# Altium schematic sheet Motor_Driver.SchDoc
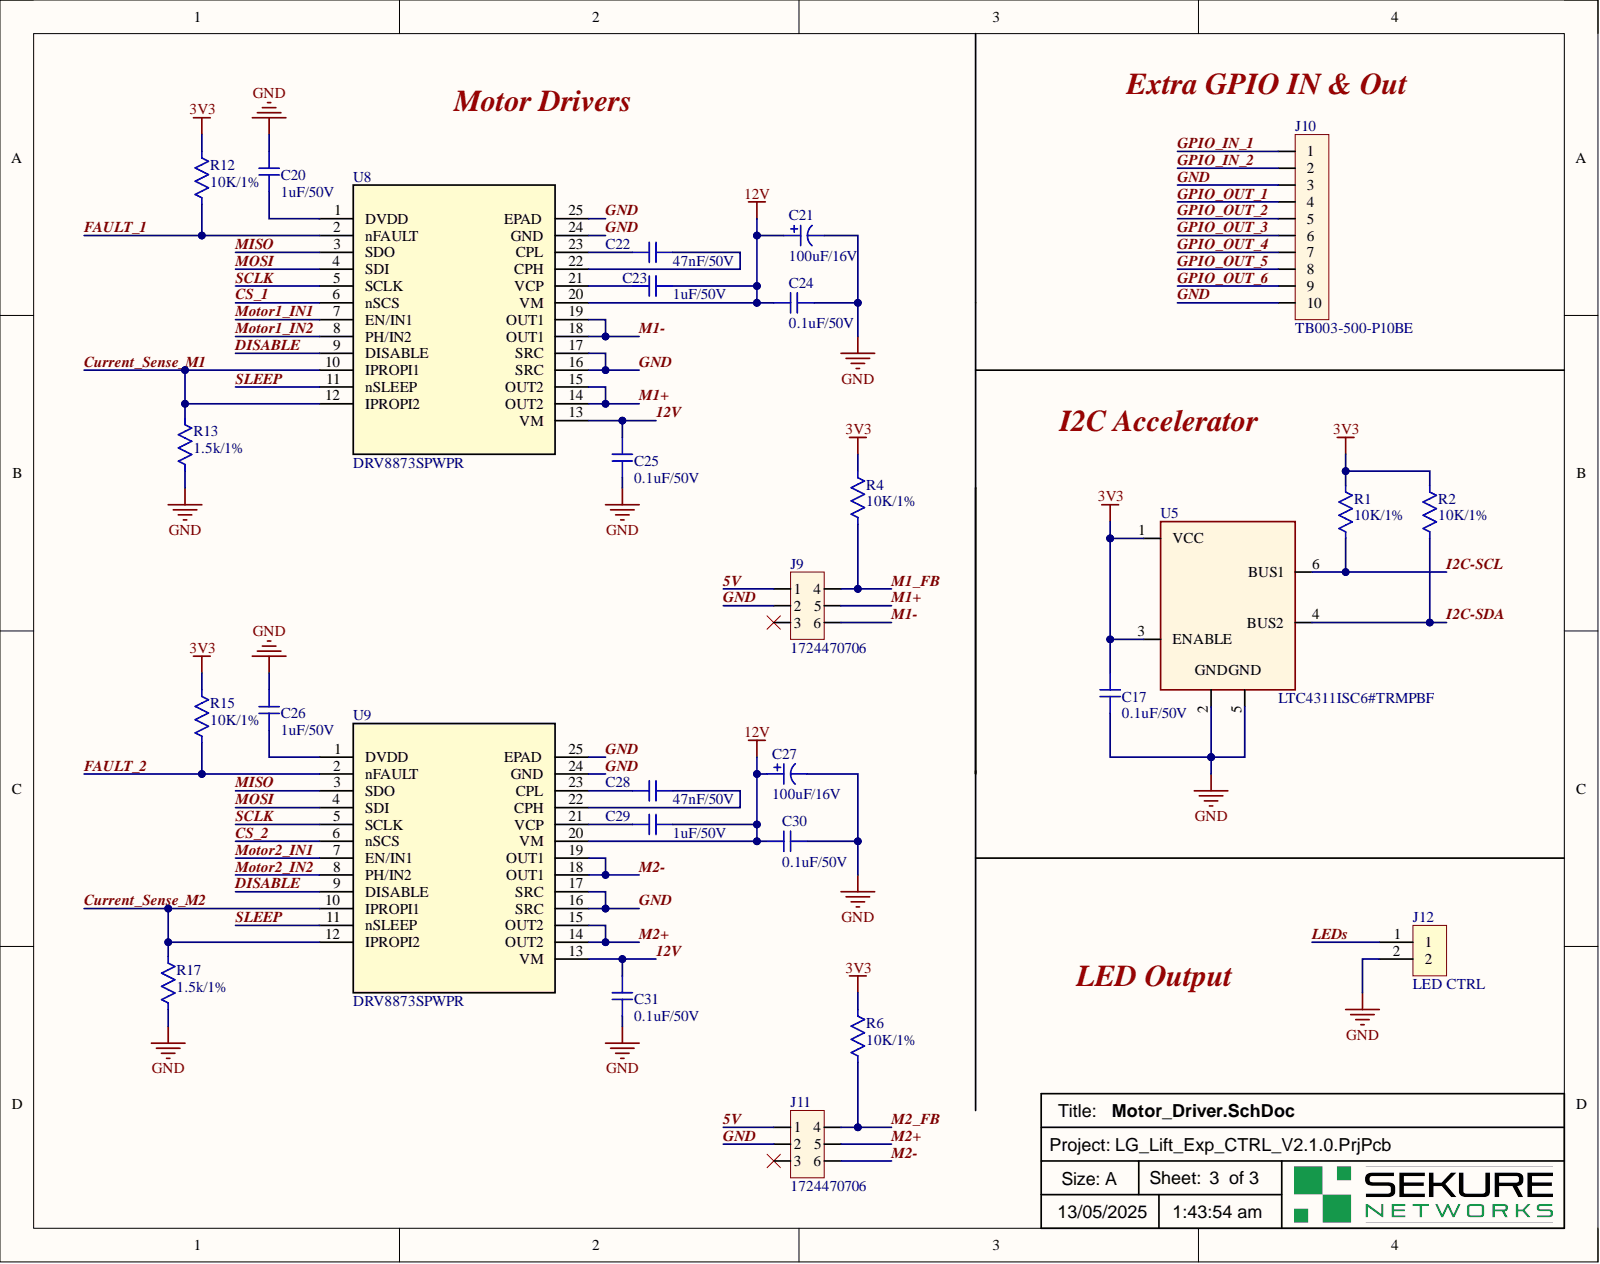
[diagram p0: Motor_Driver.SchDoc]

## Parts
C17  value 0.1uF/50V  [CAP 0603]  (hidden comment: Ceramic Capacitor)
C20  value 1uF/50V  [CAP 0603]  (hidden comment: Ceramic Capacitor)
C21  value 100uF/16V  [CAP SMD 6.6 x 6.6]  (hidden comment: Capacitor)
C22  value 47nF/50V  [CAP 0603]  (hidden comment: Ceramic Capacitor)
C23  value 1uF/50V  [CAP 0603]  (hidden comment: Ceramic Capacitor)
C24  value 0.1uF/50V  [CAP 0603]  (hidden comment: Ceramic Capacitor)
C25  value 0.1uF/50V  [CAP 0603]  (hidden comment: Ceramic Capacitor)
C26  value 1uF/50V  [CAP 0603]  (hidden comment: Ceramic Capacitor)
C27  value 100uF/16V  [CAP SMD 6.6 x 6.6]  (hidden comment: Capacitor)
C28  value 47nF/50V  [CAP 0603]  (hidden comment: Ceramic Capacitor)
C29  value 1uF/50V  [CAP 0603]  (hidden comment: Ceramic Capacitor)
C30  value 0.1uF/50V  [CAP 0603]  (hidden comment: Ceramic Capacitor)
C31  value 0.1uF/50V  [CAP 0603]  (hidden comment: Ceramic Capacitor)
J9  value 1724470706  [1724470706]  (hidden comment: Connector)
J10  value TB003-500-P10BE  [TB003-500-P10BE]  (hidden comment: Connector)
J11  value 1724470706  [1724470706]  (hidden comment: Connector)
J12  value LED CTRL  [TB003-500-P02BE]  (hidden comment: Connector)
R1  value 10K/1%  [RES 0603]  (hidden comment: Resistor)
R2  value 10K/1%  [RES 0603]  (hidden comment: Resistor)
R4  value 10K/1%  [RES 0603]  (hidden comment: Resistor)
R6  value 10K/1%  [RES 0603]  (hidden comment: Resistor)
R12  value 10K/1%  [RES 0603]  (hidden comment: Resistor)
R13  value 1.5k/1%  [RES 0603]  (hidden comment: Resistor)
R15  value 10K/1%  [RES 0603]  (hidden comment: Resistor)
R17  value 1.5k/1%  [RES 0603]  (hidden comment: Resistor)
U5  value LTC4311ISC6#TRMPBF  [SC-70-6, 6-TSSOP, SC-88, SOT-363]  (hidden comment: IC)
U8  value DRV8873SPWPR  [24-HTSSOP]  (hidden comment: IC)
U9  value DRV8873SPWPR  [24-HTSSOP]  (hidden comment: IC)

## Nets
3V3: C17.2 R1.1 R2.1 R4.2 R6.2 R12.2 R15.2 U5.1(VCC) U5.3(ENABLE)
5V: J9.1 J11.1
12V: C21.1 C23.1 C24.1 C25.2 C27.1 C29.1 C30.1 C31.1 U8.13(VM) U8.20(VM) U9.13(VM) U9.20(VM)
CS_1: U8.6(nSCS)
CS_2: U9.6(nSCS)
Current_Sense_M1: R13.2 U8.10(IPROPI1) U8.12(IPROPI2)
Current_Sense_M2: R17.2 U9.10(IPROPI1) U9.12(IPROPI2)
DISABLE: U8.9(DISABLE) U9.9(DISABLE)
FAULT_1: R12.1 U8.2(nFAULT)
FAULT_2: R15.1 U9.2(nFAULT)
GND: C17.1 C20.2 C21.2 C24.2 C25.1 C26.1 C27.2 C30.2 C31.2 J9.2 J10.3 J10.10 J11.2 J12.2 R13.1 R17.1 U5.2 U5.5 U8.16(SRC) U8.17(SRC) U8.24(GND) U8.25(EPAD) U9.16(SRC) U9.17(SRC) U9.24(GND) U9.25(EPAD)
GPIO_IN_1: J10.1
GPIO_IN_2: J10.2
GPIO_OUT_1: J10.4
GPIO_OUT_2: J10.5
GPIO_OUT_3: J10.6
GPIO_OUT_4: J10.7
GPIO_OUT_5: J10.8
GPIO_OUT_6: J10.9
I2C-SCL: R1.2 U5.6(BUS1)
I2C-SDA: R2.2 U5.4(BUS2)
LEDs: J12.1
M1+: J9.5 U8.14(OUT2) U8.15(OUT2)
M1-: J9.6 U8.18(OUT1) U8.19(OUT1)
M1_FB: J9.4 R4.1
M2+: J11.5 U9.14(OUT2) U9.15(OUT2)
M2-: J11.6 U9.18(OUT1) U9.19(OUT1)
M2_FB: J11.4 R6.1
MISO: U8.3(SDO) U9.3(SDO)
MOSI: U8.4(SDI) U9.4(SDI)
Motor1_IN1: U8.7(EN/IN1)
Motor1_IN2: U8.8(PH/IN2)
Motor2_IN1: U9.7(EN/IN1)
Motor2_IN2: U9.8(PH/IN2)
SCLK: U8.5(SCLK) U9.5(SCLK)
SLEEP: U8.11(nSLEEP) U9.11(nSLEEP)
NetC20_1: C20.1 U8.1(DVDD)
NetC22_1: C22.1 U8.22(CPH)
NetC22_2: C22.2 U8.23(CPL)
NetC23_2: C23.2 U8.21(VCP)
NetC26_2: C26.2 U9.1(DVDD)
NetC28_1: C28.1 U9.22(CPH)
NetC28_2: C28.2 U9.23(CPL)
NetC29_2: C29.2 U9.21(VCP)

Not connected: J9 3; J11 3

## Library data of the parts
C17 C24 C25 C30 C31 = C0603G104K5RAC7867: CAP CER 0.1UF 50V X7R 0603; Manufacturer: KEMET; Capacitance: 100nF; Tolerance: 10%; Case Code (Imperial): 0603; Case Code (Metric): 1608; Case/Package: 0603; Component Kind: Standard; ComponentLink1Description: http://www.kemet.com/Lists/ProductCatalog/Attachments/44/KEM_CC106_HITEMP.pdf?src-supplier=Digi-Key; ComponentLink1URL: http://www.kemet.com/Lists/ProductCatalog/Attachments/44/KEM_CC106_HITEMP.pdf?src-supplier=Digi-Key; DigiKey: 399-11919-1-ND; Features: High Temperature; Length: 0.063inch; Library Name: Ceramic Capacitors.IntLib; Max Operating Temperature: 175°C; Min Operating Temperature: -55°C; Mount: Surface Mount; P/N: C0603G104K5RAC7867; Packaging: Cut Tape; Pricing 1: 1=2.02000, 10=1.63200, 50=1.42440, 100=1.24640, 500=0.94962, 1000=0.83092 (USD); RoHS: Yes; Stock 1: 15997; Supplier 1: Digi-Key; Thickness: 0.034inch; Voltage Rating: 50V; Width: 0.031inch
C20 C23 C26 C29 = UMK107AB7105KA-T: CAP CER  1uF 50 V ±10% Tolerance X7R SMT; Manufacturer: Taiyo Yuden; Voltage Rating: 50V; Capacitance: 1uF; Case Code (Imperial): 0603; Case Code (Metric): 1608; Case/Package: 0603; Component Kind: Standard; ComponentLink1Description: https://datasheet.ciiva.com/6257/umk107ab7105ka-t-ss-6257600.pdf?src-supplier=Digi-Key; ComponentLink1URL: https://datasheet.ciiva.com/6257/umk107ab7105ka-t-ss-6257600.pdf?src-supplier=Digi-Key; ComponentLink2Description: https://datasheet.ciiva.com/15922/umk107ab7105ka-t-pdf-15922601.pdf?src-supplier=Onlinecomponents.com; ComponentLink2URL: https://datasheet.ciiva.com/15922/umk107ab7105ka-t-pdf-15922601.pdf?src-supplier=Onlinecomponents.com; ComponentLink3Description: https://datasheet.ciiva.com/30137/76umk107ab7105ka-t-30137806.pdf?src-supplier=Onlinecomponents.com; ComponentLink3URL: https://datasheet.ciiva.com/30137/76umk107ab7105ka-t-30137806.pdf?src-supplier=Onlinecomponents.com; Depth: 0.8mm; Dielectric: X7R; DigiKey: 587-3247-1-ND; Halogen Free: Halogen Free; Height: 0.8mm; Length: 1.6mm; Library Name: Ceramic Capacitors.IntLib; Max Operating Temperature: 125°C; Min Operating Temperature: -55°C; Mount: Surface Mount; P/N: UMK107AB7105KA-T; Package Quantity: 1; Packaging: Tape and Reel; Pricing 1: 1=0.25000, 10=0.17500, 100=0.09890, 500=0.06982, 1000=0.06110 (USD); Radiation Hardening: No; RoHS: Yes; Stock 1: 799212; Supplier 1: Digi-Key; Termination: SMD/SMT; Thickness: 0.037inch; Tolerance: 10%; Voltage Rating (DC): 50V; Width: 0.031inch
C21 C27 = A765EB107M1CLAE025: Aluminum Electrolytic Capacitor, Polarized, Aluminum (solid Polymer), 16V, 20% +Tol, 20% -Tol, 100uF, Surface Mount, 2626; Manufacturer: KEMET; Capacitor Type: Aluminium; Category: Polymer Capacitors; Component Kind: Standard; ComponentLink1Description: https://datasheet.ciiva.com:443/pdfs/VipMasterIC/IC/KEME/KEME-S-A0009156844/KEME-S-A0009156844-1.pdf?src-supplier=IHS+Markit; ComponentLink1URL: https://datasheet.ciiva.com:443/pdfs/VipMasterIC/IC/KEME/KEME-S-A0009156844/KEME-S-A0009156844-1.pdf?src-supplier=IHS+Markit; ComponentLink2Description: https://datasheet.octopart.com/A765EB107M1CLAE025-KEMET-datasheet-140121990.pdf?src-supplier=Farnell; ComponentLink2URL: https://datasheet.octopart.com/A765EB107M1CLAE025-KEMET-datasheet-140121990.pdf?src-supplier=Farnell; ComponentLink3Description: http://www.farnell.com/datasheets/2095663.pdf?src-supplier=Element14; ComponentLink3URL: http://www.farnell.com/datasheets/2095663.pdf?src-supplier=Element14; ComponentLink4Description: https://api.kemet.com/component-edge/download/datasheet/A765EB107M1CLAE025.pdf?src-supplier=Digikey; ComponentLink4URL: https://api.kemet.com/component-edge/download/datasheet/A765EB107M1CLAE025.pdf?src-supplier=Digikey; ComponentLink5Description: http://www.kemet.com/docfinder?Partnumber=A765EB107M1CLAE025&src-supplier=Digikey; ComponentLink5URL: http://www.kemet.com/docfinder?Partnumber=A765EB107M1CLAE025&src-supplier=Digikey; ComponentLink6Description: https://datasheet.octopart.com/A765EB107M1CLAE025-KEMET-datasheet-74830868.pdf?src-supplier=Newark; ComponentLink6URL: https://datasheet.octopart.com/A765EB107M1CLAE025-KEMET-datasheet-74830868.pdf?src-supplier=Newark; ComponentLink7Description: https://datasheet.octopart.com/A765EB107M1CLAE025-KEMET-datasheet-165572898.pdf?src-supplier=TME; ComponentLink7URL: https://datasheet.octopart.com/A765EB107M1CLAE025-KEMET-datasheet-165572898.pdf?src-supplier=TME; ComponentLink8Description: https://datasheet.octopart.com/A765EB107M1CLAE025-KEMET-datasheet-138896607.pdf?src-supplier=Electro+Sonic; ComponentLink8URL: https://datasheet.octopart.com/A765EB107M1CLAE025-KEMET-datasheet-138896607.pdf?src-supplier=Electro+Sonic; Dielectric Material: Aluminium; DigiKey: 399-13672-1-ND; Library Name: Radial Capacitors.IntLib; P/N: A765EB107M1CLAE025; Pins: 2; Pricing: 1=0.69, 10=0.482, 50=0.4062, 100=0.3307, 500=0.27556 (USD); Reach SVHC Compliant: Yes; RoHS: Yes; RoHS Compliant: Yes; Stock: 4291; Supplier: Digikey
C22 C28 = GRM188R71H473KA61D: CAP CER 47nF, 50 V,  10%, X7R, GRM Series; Manufacturer: Murata; Insulation Resistance: 10GR; Voltage: 50V; Capacitance: 47nF; Case Code (Imperial): 0603; Case Code (Metric): 1608; Case/Package: 0603; Component Kind: Standard; ComponentLink1Description: https://datasheet.ciiva.com/132/grm188r71h473ka61-01-132379.pdf?src-supplier=Digi-Key; ComponentLink1URL: https://datasheet.ciiva.com/132/grm188r71h473ka61-01-132379.pdf?src-supplier=Digi-Key; ComponentLink2Description: https://datasheet.ciiva.com/132/grm188r71h473ka61-01-132380.pdf?src-supplier=Digi-Key; ComponentLink2URL: https://datasheet.ciiva.com/132/grm188r71h473ka61-01-132380.pdf?src-supplier=Digi-Key; ComponentLink3Description: https://datasheet.ciiva.com/11786/0900766b807a1cab-11786316.pdf?src-supplier=RSComponents; ComponentLink3URL: https://datasheet.ciiva.com/11786/0900766b807a1cab-11786316.pdf?src-supplier=RSComponents; ComponentLink4Description: https://datasheet.ciiva.com/132/grm188r71h473ka61-01-132381.pdf?src-supplier=Digi-Key; ComponentLink4URL: https://datasheet.ciiva.com/132/grm188r71h473ka61-01-132381.pdf?src-supplier=Digi-Key; ComponentLink5Description: https://datasheet.ciiva.com/18101/getdatasheetpartid-38124-18101149.pdf?src-supplier=Verical; ComponentLink5URL: https://datasheet.ciiva.com/18101/getdatasheetpartid-38124-18101149.pdf?src-supplier=Verical; ComponentLink6Description: https://datasheet.ciiva.com/29201/451904-da-01-en-vs-kondensator-0603-29201838.pdf?src-supplier=Conrad; ComponentLink6URL: https://datasheet.ciiva.com/29201/451904-da-01-en-vs-kondensator-0603-29201838.pdf?src-supplier=Conrad; ComponentLink7Description: https://datasheet.ciiva.com/7937/4360-7937672.pdf?src-supplier=Element14; ComponentLink7URL: https://datasheet.ciiva.com/7937/4360-7937672.pdf?src-supplier=Element14; ComponentLink8Description: https://datasheet.ciiva.com/14116/nmgrm-data-en-14116600.pdf?src-supplier=Distrelec; ComponentLink8URL: https://datasheet.ciiva.com/14116/nmgrm-data-en-14116600.pdf?src-supplier=Distrelec; ComponentLink9Description: https://datasheet.ciiva.com/7937/4360-7937673.pdf?src-supplier=Element14; ComponentLink9URL: https://datasheet.ciiva.com/7937/4360-7937673.pdf?src-supplier=Element14; ComponentLink10Description: https://datasheet.ciiva.com/48436/nmgrm-data-en-48436665.pdf?src-supplier=Distrelec; ComponentLink10URL: https://datasheet.ciiva.com/48436/nmgrm-data-en-48436665.pdf?src-supplier=Distrelec; ComponentLink11Description: https://datasheet.ciiva.com/7937/4360-7937674.pdf?src-supplier=Element14; ComponentLink11URL: https://datasheet.ciiva.com/7937/4360-7937674.pdf?src-supplier=Element14; ComponentLink12Description: https://datasheet.ciiva.com/5427/product-5427340.pdf?src-supplier=Mouser; ComponentLink12URL: https://datasheet.ciiva.com/5427/product-5427340.pdf?src-supplier=Mouser; ComponentLink13Description: https://datasheet.ciiva.com/16393/product-16393388.pdf?src-supplier=Future+Electronics; ComponentLink13URL: https://datasheet.ciiva.com/16393/product-16393388.pdf?src-supplier=Future+Electronics; Depth: 0.8mm; Dielectric: X7R; Dielectric Material: Ceramic; DigiKey: 490-3287-1-ND; Height: 0.8mm; Lead Free: Lead Free; Length: 1.6mm; Library Name: Ceramic Capacitors.IntLib; Material: Ceramic; Max Operating Temperature: 125°C; Min Operating Temperature: -55°C; Mount: Surface Mount; Number of Pins: 2; P/N: GRM188R71H473KA61D; Package Quantity: 1; Packaging: Tape and Reel; Radiation Hardening: No; REACH SVHC: No SVHC; RoHS: Yes; Stock 1: 0; Supplier 1: Digi-Key; Termination: SMD/SMT; Thickness: 0.035inch; Tolerance: 10%; Voltage Rating: 50V; Voltage Rating (DC): 50V; Width: 0.031inch
J9 J11 = 1724470706: Connector Header Through Hole 6 position 0.165" (4.20mm); Manufacturer: Molex; Component Kind: Standard; DigiKey: 900-1724470706-ND; Library Name: Connectors.IntLib; P/N: 1724470706; Pricing: 1=0.25, 10=0.202, 280=0.14839, 1120=0.11875 (USD); RoHS: No; Stock: 12275; Supplier: Digikey
J10 = TB003-500-P10BE: Barrier Strip Terminal Block; Manufacturer: CUI Devices; Category: Terminal Blocks; Component Kind: Standard; DigiKey: 102-6169-ND; Library Name: Connectors.IntLib; P/N: TB003-500-P10BE; Pricing: 1=1.34, 10=0.94, 25=0.8768, 50=0.7958, 100=0.7924, 250=0.66912, 740=0.63389, 1480=0.62 (USD); RoHS: Yes; Stock: 557; Supplier: Digikey
J12 = TB003-500-P02BE: 2~24 Poles, Screw Type, Horizontal, 5.0 Pitch, 26~14 (AWG), Terminal Block Connector; Manufacturer: CUI Devices; Pitch: 5mm; Category: Terminal Blocks; Component Kind: Standard; DigiKey: 102-6161-ND; Height: 10.3mm; Library Name: Connectors.IntLib; Orientation: 5496OrientationType; P/N: TB003-500-P02BE; Pricing: 1=0.35, 10=0.194, 25=0.1448, 50=0.1292, 100=0.1266, 250=0.11144, 500=0.10132, 1000=0.09119, 5000=0.08919 (USD); RoHS: Yes; Stock: 49179; Supplier: Digikey; Width: 7.8mm
R1 R2 R4 R6 R12 R15 = RC0603FR-0710KL: RES SMD 10K OHM 1% 1/10W 0603; Manufacturer: Yageo; Power Rating: 100mW; Resistance: 10kR; Temperature Coefficient: 100ppm/°C; Voltage Rating: 75V; Voltage Rating (DC): 50V; Case Code (Imperial): 0603; Case Code (Metric): 1608; Case/Package: 0603; Component Kind: Standard; ComponentLink1Description: https://datasheet.ciiva.com/7660/38590-7660789.pdf?src-supplier=Element14; ComponentLink1URL: https://datasheet.ciiva.com/7660/38590-7660789.pdf?src-supplier=Element14; ComponentLink2Description: https://datasheet.ciiva.com/15189/getdatasheetpartid-409289-15189591.pdf?src-supplier=Verical; ComponentLink2URL: https://datasheet.ciiva.com/15189/getdatasheetpartid-409289-15189591.pdf?src-supplier=Verical; ComponentLink3Description: https://datasheet.ciiva.com/46440/1868787-46440891.pdf?src-supplier=Element14; ComponentLink3URL: https://datasheet.ciiva.com/46440/1868787-46440891.pdf?src-supplier=Element14; ComponentLink4Description: https://datasheet.ciiva.com/7983/443971-7983596.pdf?src-supplier=Element14; ComponentLink4URL: https://datasheet.ciiva.com/7983/443971-7983596.pdf?src-supplier=Element14; ComponentLink5Description: https://datasheet.ciiva.com/7746/1738695-7746239.pdf?src-supplier=Element14; ComponentLink5URL: https://datasheet.ciiva.com/7746/1738695-7746239.pdf?src-supplier=Element14; ComponentLink6Description: https://datasheet.ciiva.com/22747/443971-22747519.pdf?src-supplier=Element14; ComponentLink6URL: https://datasheet.ciiva.com/22747/443971-22747519.pdf?src-supplier=Element14; Composition: Thick Film; Depth: 0.8mm; DigiKey: 311-10.0KHRDKR-ND; Height: 0.45mm; Lead Free: Lead Free; Lead Pitch: 0.3mm; Length: 1.6mm; Library Name: Resistors.IntLib; Max Operating Temperature: 155°C; Military Standard: Not; Min Operating Temperature: -55°C; Number of Pins: 2; P/N: RC0603FR-0710KL; Packaging: Tape and Reel; Pricing 1: 1=0.10000, 10=0.02300, 100=0.00940, 1000=0.00421, 2500=0.00366 (USD); REACH SVHC: No SVHC; RoHS: Yes; Stock 1: 55833360; Supplier 1: Digi-Key; Termination: SMD/SMT; Tolerance: 1%; Weight: 7.1E-05oz; Width: 0.8mm
R13 R17 = RC0603FR-071K5L: Fixed Resistor, Metal Glaze/thick Film, 0.1W, 1500ohm, 75V, 1% +/-Tol, 100ppm/Cel, Surface Mount, 0603; Manufacturer: Yageo; Additional Feature: LASER TRIMMABLE; Package Height: 0.45mm; Package Length: 1.6mm; Package Width: 0.8mm; Power: 100mW; Rated Temperature: 70°C; Temperature Coefficient: 100ppm/°C; Case/Package: 0603; Category: Chip SMD Resistors; Component Kind: Standard; ComponentLink1Description: https://datasheet.ciiva.com:443/pdfs/VipMasterIC/IC/YAGO/YAGO-S-A0008145938/YAGO-S-A0008145938-1.pdf?src-supplier=IHS+Markit; ComponentLink1URL: https://datasheet.ciiva.com:443/pdfs/VipMasterIC/IC/YAGO/YAGO-S-A0008145938/YAGO-S-A0008145938-1.pdf?src-supplier=IHS+Markit; Construction: Chip; DigiKey: 311-1.50KHRCT-ND; JESD-609 Code: e3; Library Name: Resistors.IntLib; Max Operating Temperature: 155°C; Min Operating Temperature: -55°C; Mounting Technology: Surface Mount; P/N: RC0603FR-071K5L; Package Shape: Rectangular; Package Style: SMTMeter; Packaging: Tape and Reel; Pins: 2; Pricing: 1=0.1, 10=0.019, 50=0.0112, 100=0.0093, 500=0.00622, 1000=0.00532 (USD); Reach SVHC Compliant: Yes; Resistor Type: FIXED RESISTOR; RoHS: Yes; RoHS Compliant: Yes; Stock: 853170; Supplier: Digikey; Technology: METAL GLAZE/THICK FILM; Terminal Finish: Nickel; Termination: Wraparound; Tolerance: 1%; Working Voltage: 75V
U5 = LTC4311ISC6#TRMPBF: IC ACCELERATOR I2C 1CH SC70-6; Manufacturer: Analog Devices / Linear Technology; Operating Supply Current: 300uA; Case/Package: SC; Category: Interface ICs; Component Kind: Standard; ComponentLink1Description: https://datasheet.ciiva.com/15134/getdatasheetpartid-364069-15134818.pdf?src-supplier=Verical; ComponentLink1URL: https://datasheet.ciiva.com/15134/getdatasheetpartid-364069-15134818.pdf?src-supplier=Verical; ComponentLink2Description: https://datasheet.ciiva.com/1783/26068-1783072.pdf?src-supplier=Digi-Key; ComponentLink2URL: https://datasheet.ciiva.com/1783/26068-1783072.pdf?src-supplier=Digi-Key; ComponentLink3Description: https://datasheet.ciiva.com/1783/26068-1783073.pdf?src-supplier=Digi-Key; ComponentLink3URL: https://datasheet.ciiva.com/1783/26068-1783073.pdf?src-supplier=Digi-Key; ComponentLink4Description: https://datasheet.ciiva.com/1783/26068-1783074.pdf?src-supplier=Digi-Key; ComponentLink4URL: https://datasheet.ciiva.com/1783/26068-1783074.pdf?src-supplier=Digi-Key; DigiKey: LTC4311ISC6#TRMPBFDKR-ND; Lead Free: Lead Free; Library Name: IC's.SchLib; Max Operating Temperature: 85°C; Min Operating Temperature: -40°C; Mount: Surface Mount; Number of Pins: 70; P/N: LTC4311ISC6#TRMPBF; Package Quantity: 1; Packaging: Tape and Reel; Pricing 1: 1=5.62000, 10=5.04600, 25=4.77000, 100=4.13400, 250=3.92200 (USD); Radiation Hardening: No; RoHS: Yes; RoHS Compliant: Yes; Series: -; Supplier 1: Digi-Key
U8 U9 = DRV8873SPWPRQ1: Brush DC Motor Controller, PDSO24; Manufacturer: Texas Instruments; Category: Motor Drivers; Component Kind: Standard; ComponentLink1Description: https://www.ti.com:443/lit/ds/symlink/drv8873-q1.pdf?src-supplier=IHS+Markit; ComponentLink1URL: https://www.ti.com:443/lit/ds/symlink/drv8873-q1.pdf?src-supplier=IHS+Markit; ComponentLink2Description: https://www.ti.com/lit/ds/symlink/drv8873-q1.pdf?src-supplier=Digikey; ComponentLink2URL: https://www.ti.com/lit/ds/symlink/drv8873-q1.pdf?src-supplier=Digikey; ComponentLink3Description: http://www.ti.com/general/docs/suppproductinfo.tsp?distId=10&amp;gotoUrl=http%3A%2F%2Fwww.ti.com%2Flit%2Fgpn%2Fdrv8873-q1&src-supplier=Digikey; ComponentLink3URL: http://www.ti.com/general/docs/suppproductinfo.tsp?distId=10&amp;gotoUrl=http%3A%2F%2Fwww.ti.com%2Flit%2Fgpn%2Fdrv8873-q1&src-supplier=Digikey; DigiKey: 296-50275-1-ND; JESD-609 Code: e4; Library Name: IC's.IntLib; Moisture Sensitivity Level: 3; P/N: DRV8873SPWPRQ1; Pricing: 1=6.34, 10=4.754, 25=4.2264, 50=4.0148, 100=3.9092, 500=3.2753, 1000=3.064 (USD); Reach SVHC Compliant: Yes; RoHS: Yes; RoHS Compliant: Yes; Stock: 7334; Supplier: Digikey; Terminal Finish: Nickel; Throw Configuration: BRUSH DC MOTOR CONTROLLER
